# Altium schematic sheet Sheet1.SchDoc(Sheet1)
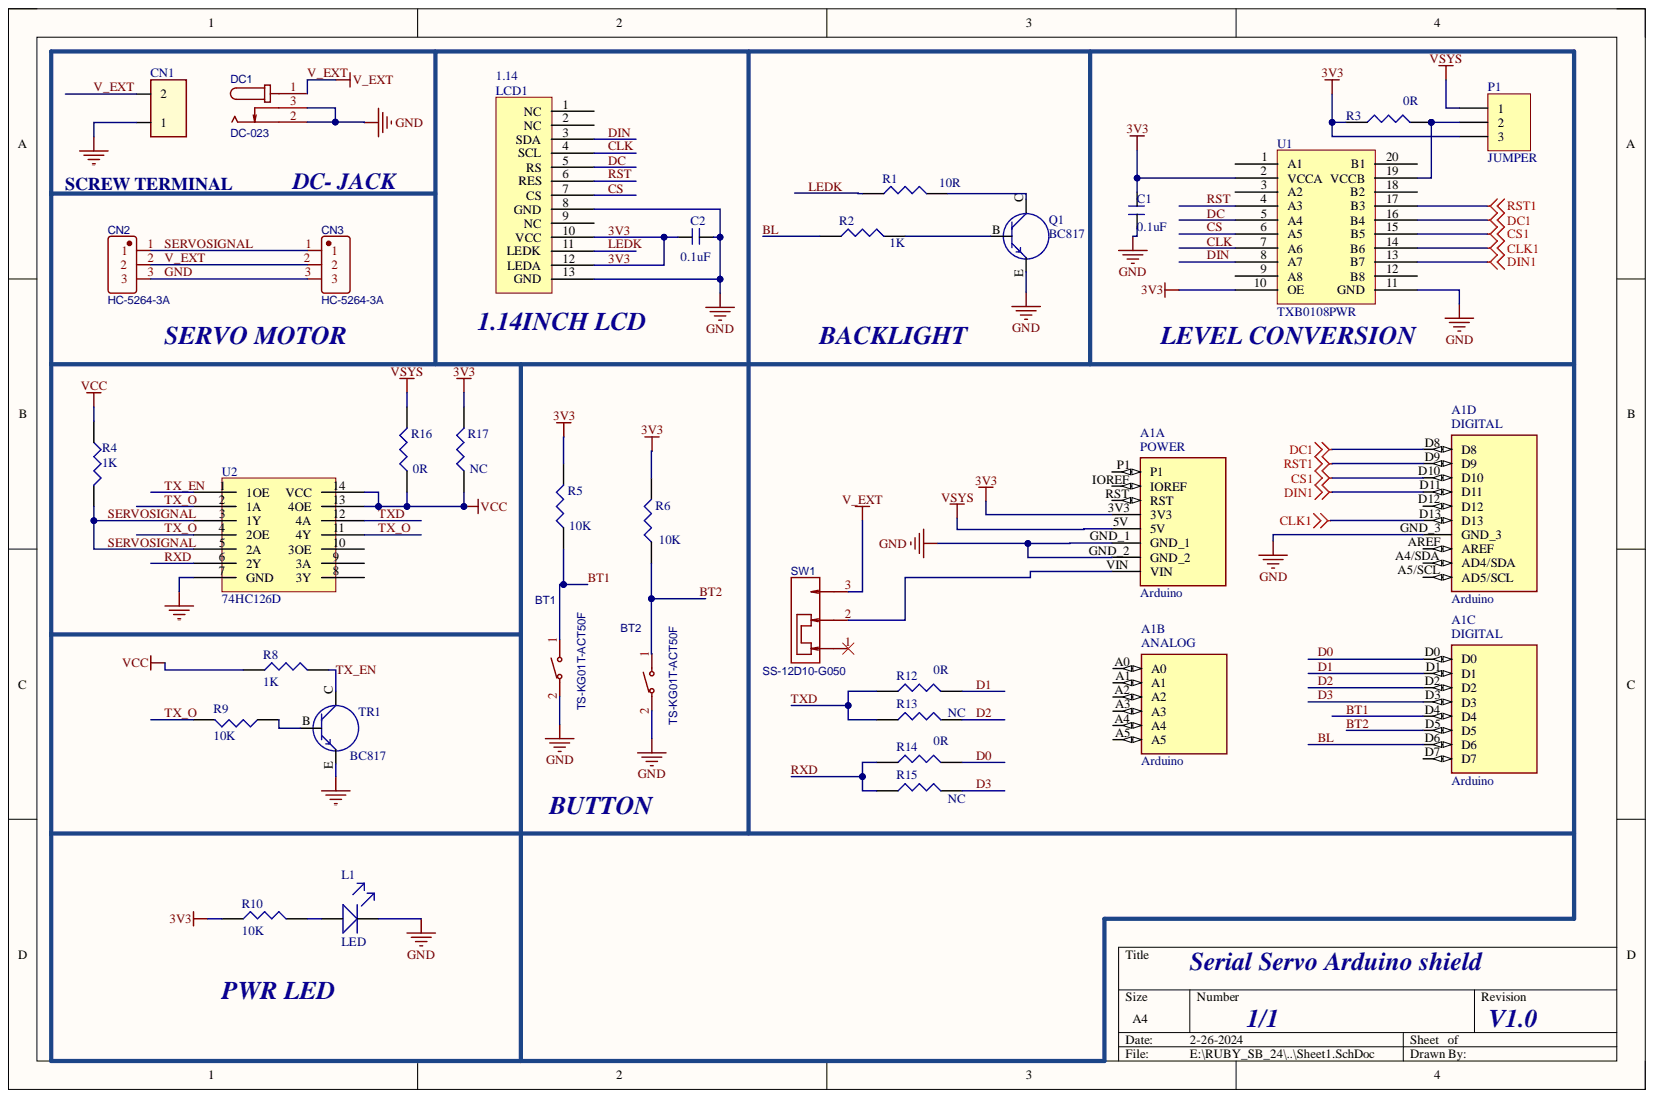
[diagram p0: Sheet1.SchDoc]

## Parts
A1  Arduino  [Arduino]  (parts A,B,C,D)
BT1  TS-KG01T-ACT50F  [SW_2P SMD]
BT2  TS-KG01T-ACT50F  [SW_2P SMD]
C1  0.1uF  [C_0603]
C2  0.1uF  [C_0603]
CN1  SCREW TERMINAL  [TERMINAL 2P_5mm]
CN2  HC-5264-3A  [1X3P P-2.5MM SERVO]
CN3  HC-5264-3A  [1X3P P-2.5MM SERVO]
DC1  DC-023  [DC-JACK_DC023]
L1  LED  [LED_0603]
LCD1  1.14  [1.14 inch LCD]
P1  JUMPER  [HDR1X3]
Q1  BC817  [SOT23]
R1  10R  [R_0603]
R2  1K  [R_0603]
R3  0R  [R_0603]
R4  1K  [R_0603]
R5  10K  [R_0603]
R6  10K  [R_0603]
R8  1K  [R_0603]
R9  10K  [R_0603]
R10  10K  [R_0603]
R12  0R  [R_0603]
R13  NC  [R_0603]
R14  0R  [R_0603]
R15  NC  [R_0603]
R16  0R  [R_0603]
R17  NC  [R_0603]
SW1  SS-12D10-G050  [SLIDE SW_Straight 3A SPDT]
TR1  BC817  [SOT23]
U1  TXB0108PWR  [TSSOP 20P]
U2  74HC126D  [SOIC-14]

## Nets
CLK1: A1.D13 U1.14(B6)
CS1: A1.D10 U1.15(B5)
DC1: A1.D8 U1.16(B4)
DIN1: A1.D11 U1.13(B7)
RST1: A1.D9 U1.17(B3)
VCC: R4.1 R8.1 R16.1 R17.1 U2.13(4OE) U2.14(VCC)
VSYS: A1.5V P1.1 R16.2
3V3: A1.3V3 C1.1 C2.2 LCD1.10(VCC) LCD1.12(LEDA) P1.3 R3.1 R5.2 R6.2 R10.1 R17.2 U1.2(VCCA) U1.10(OE)
BL: A1.D6 R2.1
BT1: A1.D4 BT1.1 R5.1
BT2: A1.D5 BT2.1 R6.1
CLK: LCD1.4(SCL) U1.7(A6)
CS: LCD1.7(CS) U1.6(A5)
D0: A1.D0 R14.2
D1: A1.D1 R12.2
D2: A1.D2 R13.2
D3: A1.D3 R15.2
DC: LCD1.5(RS) U1.5(A4)
DIN: LCD1.3(SDA) U1.8(A7)
GND: A1.GND_1 A1.GND_2 A1.GND_3 BT1.2 BT2.2 C1.2 C2.1 CN1.1 CN2.3 CN3.3 DC1.2 DC1.3 L1.K LCD1.8(GND) LCD1.13(GND) Q1.E TR1.E U1.11(GND) U2.7(GND)
LEDK: LCD1.11(LEDK) R1.1
RST: LCD1.6(RES) U1.4(A3)
RXD: R14.1 R15.1 U2.6(2Y)
SERVOSIGNAL: CN2.1 CN3.1 R4.2 U2.3(1Y) U2.5(2A)
TX_EN: R8.2 TR1.C U2.1(1OE)
TX_O: R9.2 U2.2(1A) U2.4(2OE) U2.11(4Y)
TXD: R12.1 R13.1 U2.12(4A)
V_EXT: CN1.2 CN2.2 CN3.2 DC1.1 SW1.3
NetA1_VIN: A1.VIN SW1.2
NetL1_A: L1.A R10.2
NetP1_2: P1.2 R3.2 U1.19(VCCB)
NetQ1_B: Q1.B R2.2
NetQ1_C: Q1.C R1.2
NetR9_1: R9.1 TR1.B

Not connected: A1 A0 A1 A2 A3 A4 A4/SDA(AD4/SDA) A5 A5/SCL(AD5/SCL) AREF D7 D12 IOREF P1 RST; LCD1 1(NC) 2(NC) 9(NC); SW1 1; U1 1(A1) 3(A2) 9(A8) 12(B8) 18(B2) 20(B1); U2 8(3Y) 9(3A) 10(3OE)

## Library data of the parts
A1 = Arduino: Microcontroller board, Uno [Arduino] A000066 Microcontroller board, Uno 7640152110092 <a href="https://pricing.snapeda.com/parts/A000066/Arduino/view-part?ref=eda">Check prices</a> <a href="https://pricing.snapeda.com/parts/A000066/Arduino/view-part?ref=eda">Check prices</a>; MP: ARDUINO UNO REV3; Availability: Unavailable; Component Kind: Standard; Package: None; Price: None; Library Name: MIX.SchLib
BT1 BT2 = TS-KG01T-ACT50F: BOM_Manufacturer: HANBO(汉博); Contributor: LCSC; BOM_JLCPCB Part Class: Extended Part; BOM_Manufacturer Part: TS-KG01T-ACT50F; BOM_Supplier: LCSC; BOM_Supplier Part: C2874211; Component Kind: Standard; spicePre: S; spiceSymbolName: TS-KG01T-ACT50F
C1 C2 = CAP_NONPOLAR: Component Kind: Standard; Library Name: dxp_pasl_lib.IntLib
CN1 = CON2: Component Kind: Standard; Library Name: dxp_pasl_lib.IntLib
CN2 CN3 = HC-5264-3A: Manufacturer: HCTL(华灿天禄); Contributor: LCSC; Component Kind: Standard; JLCPCB Part Class: Extended Part; Manufacturer Part: HC-5264-3A; spicePre: C; spiceSymbolName: HC-5264-3A; Supplier: LCSC; Supplier Part: C2845815
DC1 = DC_JACK: BOM_Manufacturer: XKB Connectivity(中国星坤); Contributor: LCSC; BOM_JLCPCB Part Class: Extended Part; BOM_Manufacturer Part: DC-023; BOM_Supplier: LCSC; BOM_Supplier Part: C2689666; Component Kind: Standard; Description: DC-023; spicePre: D; spiceSymbolName: DC-023; Library Name: MIX.SchLib
LCD1 = LCD 1.14INCH: 1.14inch LCD Display 65K RGB Colors, 240×135 Pixels, SPI Interface; Component Kind: Standard; Library Name: dxp_pasl_lib.IntLib
P1 = Header 3: Header, 3-Pin; Component Kind: Standard; LatestRevisionDate: 17-Jul-2002; LatestRevisionNote: Re-released for DXP Platform.; Library Name: Miscellaneous Connectors.IntLib; Signal Integrity: Connector; Publisher: Altium Limited
Q1 TR1 = TRANSISTER_NPN: Component Kind: Standard; Library Name: dxp_pasl_lib.IntLib
R1-R6 R8-R10 R12-R17 = RES: Component Kind: Standard; Library Name: dxp_pasl_lib.IntLib
SW1 = SS-12D10-G050: Manufacturer: G-Switch(品赞); Contributor: LCSC; Component Kind: Standard; JLCPCB Part Class: Extended Part; Manufacturer Part: SS-12D10-G050; spicePre: S; spiceSymbolName: SS-12D10-G050; Supplier: LCSC; Supplier Part: C2848933
U1 = TXB0108EPWR 2: Level Translators, Shifters RoHS TSSOP-20 # C53406; Component Kind: Standard; Library Name: dxp_pasl_lib.IntLib
L1 = LED: Component Kind: Standard; Library Name: dxp_pasl_lib.IntLib
U2 = 74HC126D: Component Kind: Standard; Library Name: dxp_pasl_lib.IntLib
